# Altium schematic sheet [3] Alimentation tension di.SchDoc("[3] Alimentation tension di")
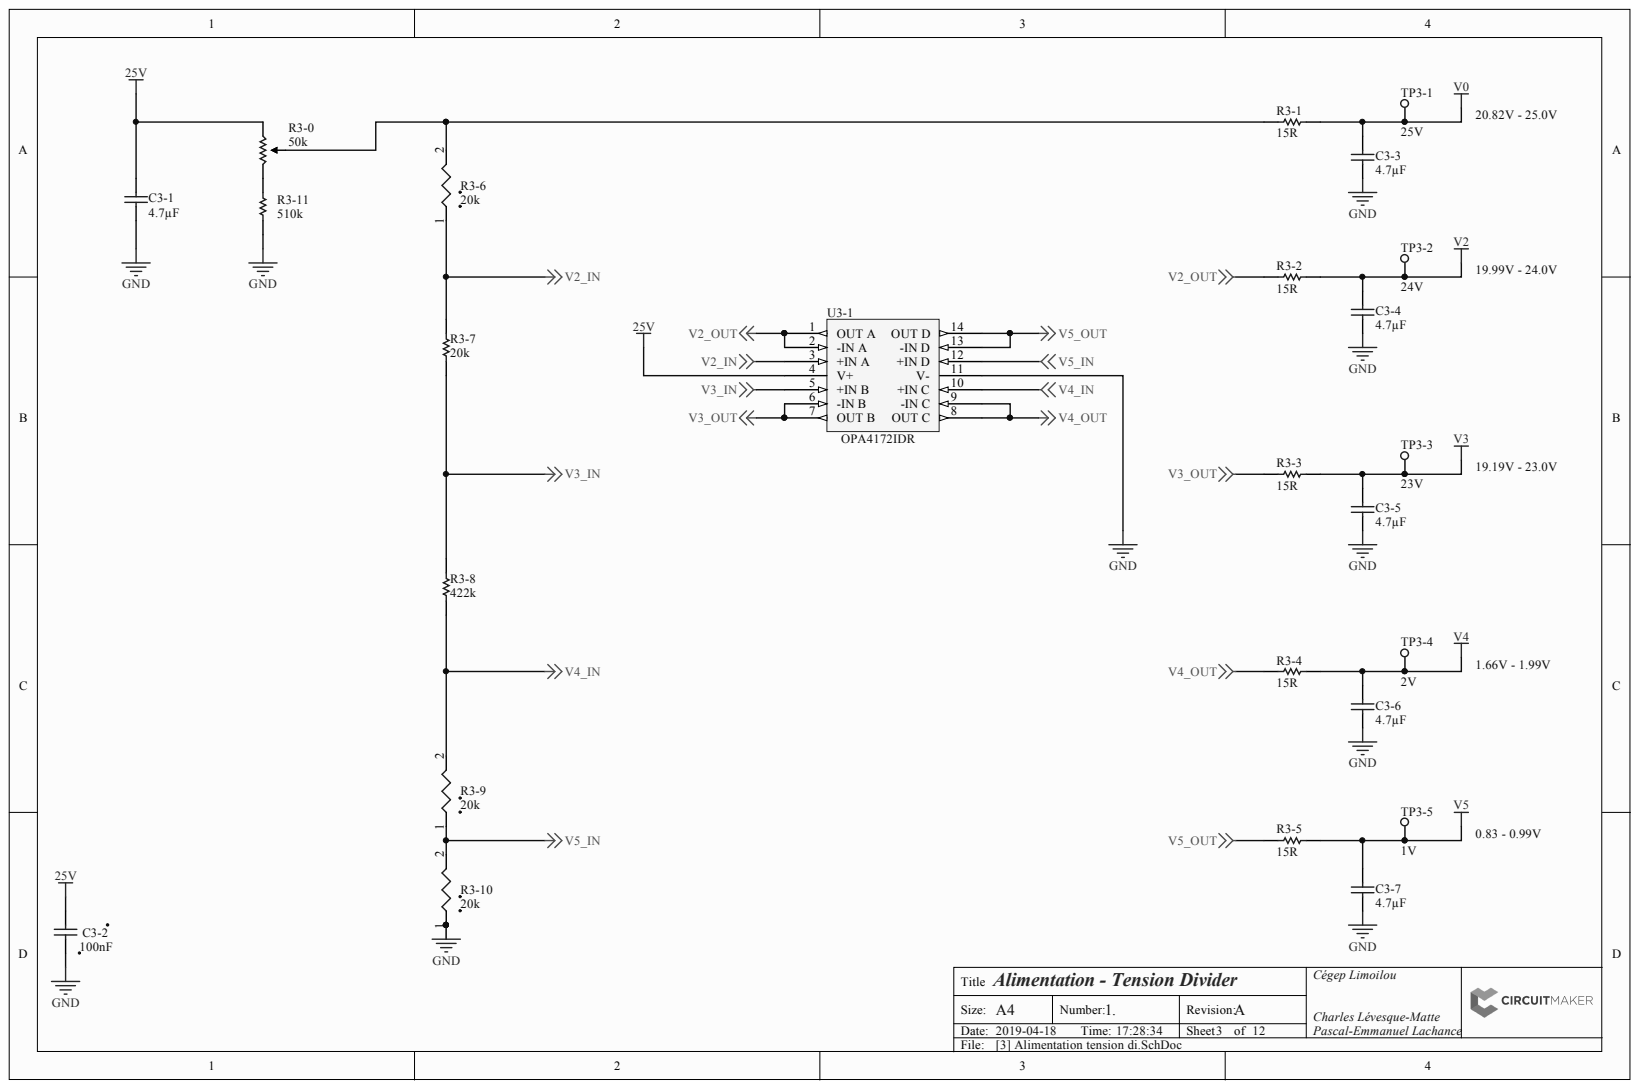
[diagram p0: [3] Alimentation tension di.SchDoc]

## Parts
C3-1  4.7µF  [CAPC3216X180X55ML20T25]
C3-2  100nF  [CAPC1608X90X35ML15T15]
C3-3  4.7µF  [CAPC3216X180X55ML20T25]
C3-4  4.7µF  [CAPC3216X180X55ML20T25]
C3-5  4.7µF  [CAPC3216X180X55ML20T25]
C3-6  4.7µF  [CAPC3216X180X55ML20T25]
C3-7  4.7µF  [CAPC3216X180X55ML20T25]
R3-0  50k  [PCB-vm906pmh4lcf99g1rjc6-1]
R3-1  15R  [PCB-pscu45pf2gecywnmi9sb-1]
R3-2  15R  [PCB-pscu45pf2gecywnmi9sb-1]
R3-3  15R  [PCB-pscu45pf2gecywnmi9sb-1]
R3-4  15R  [PCB-pscu45pf2gecywnmi9sb-1]
R3-5  15R  [PCB-pscu45pf2gecywnmi9sb-1]
R3-6  20k  [PCB-jr3gnteqc9p6l8jw436q-1]
R3-7  20k  [PCB-jr3gnteqc9p6l8jw436q-1]
R3-8  422k  [PCB-r78kbkcw3v9m4vgc944j-1]
R3-9  20k  [PCB-jr3gnteqc9p6l8jw436q-1]
R3-10  20k  [PCB-jr3gnteqc9p6l8jw436q-1]
R3-11  510k  [PCB-fzhvmcfjk8m6ifi8yfyl-1]
TP3-1  25V  [PCB-a7rpxwhzqdkz91v608la-1]
TP3-2  24V  [PCB-a7rpxwhzqdkz91v608la-1]
TP3-3  23V  [PCB-a7rpxwhzqdkz91v608la-1]
TP3-4  2V  [PCB-a7rpxwhzqdkz91v608la-1]
TP3-5  1V  [PCB-a7rpxwhzqdkz91v608la-1]
U3-1  OPA4172IDR  [PCB-a2i7bs9dgoylt2kcgvdk-1]

## Nets
25V: C3-1.2 C3-2.2 R3-0.3 U3-1.4(V+)
GND: C3-1.1 C3-2.1 C3-3.1 C3-4.1 C3-5.1 C3-6.1 C3-7.1 R3-10.1 R3-11.1 U3-1.11(V-)
V0: C3-3.2 R3-1.2 TP3-1.1
V2: C3-4.2 R3-2.2 TP3-2.1
V2_IN: R3-6.1 R3-7.2 U3-1.3(+IN_A)
V2_OUT: R3-2.1 U3-1.1(OUT_A) U3-1.2(-IN_A)
V3: C3-5.2 R3-3.2 TP3-3.1
V3_IN: R3-7.1 R3-8.2 U3-1.5(+IN_B)
V3_OUT: R3-3.1 U3-1.6(-IN_B) U3-1.7(OUT_B)
V4: C3-6.2 R3-4.2 TP3-4.1
V4_IN: R3-8.1 R3-9.2 U3-1.10(+IN_C)
V4_OUT: R3-4.1 U3-1.8(OUT_C) U3-1.9(-IN_C)
V5: C3-7.2 R3-5.2 TP3-5.1
V5_IN: R3-9.1 R3-10.2 U3-1.12(+IN_D)
V5_OUT: R3-5.1 U3-1.13(-IN_D) U3-1.14(OUT_D)
NetR3-0_1: R3-0.1 R3-11.2
NetR3-0_2: R3-0.2 R3-1.1 R3-6.2

## Library data of the parts
C3-1 C3-3-C3-7 = CMP-7356bb72f6b9ac91-2: CL31 Series 4.7 uF 50 V ±10 % Tolerance X7R SMT Multilayer Ceramic Capacitor; Manufacturer Part Number: CL31B475KBHNNNE; Component Kind: Standard; Dielectric Characteristic: X7R; Library Name: CommunityVault; OctopartID: 7356bb72f6b9ac91; Octopart URL: http://octopart.com/cl31b475kbhnnne-samsung-19832969; RoHS: Compliant
C3-2 = CMP-1af8d38ac64bc53f-2: CL10 Series 0.1 uF 50 V ±10% Tolerance X7R SMT Multilayer Ceramic Capacitor; Capacitance: 100 nF; Capacitance Tolerance: ±10%; Component Kind: Standard; Library Name: CommunityVault; Mounting Style: Surface Mount; OctopartID: 1af8d38ac64bc53f; Octopart URL: http://octopart.com/cl10b104kb8nnnc-samsung-7928277; RoHS: Compliant
R3-0 = CMP-53de9ad644d18c19-2: Trimmer Potentiometer 50k Ohm 10% 1/2 Watt 1 Turn 3.15mm Pin Through Hole; Manufacturer Part Number: 3386F-1-503LF; Lead-Free Status: Lead Free; Power Rating: 500 mW; Resistance: 50.0 k?; Temperature Coefficient: -100 ppm/°C to 100 ppm/°C; Voltage Rating (DC): 300 V; Color: Blue; Component Kind: Standard; Library Name: FavoritesLibrary; Mounting Style: Through Hole; OctopartID: 53de9ad644d18c19; Octopart URL: https://octopart.com/3386f-1-503lf-bourns-143977; Operating Temperature: 125 °C (max); Packaging: Tube; REACH SVHC Compliance: No SVHC; Resistance Tolerance: ±10%; RoHS: Compliant; Size-Height: 9.53 mm; Size-Length: 9.53 mm; Size-Width: 4.83 mm; Weight: 845 mg
R3-1-R3-5 = CMP-5db1959e532f8276-1: Res Thick Film 0603 5.1K Ohm 1% 0.1W(1/10W) ±100ppm/C Pad SMD Automotive T/R; Manufacturer Part Number: RMCF0603FT5K10; Lead-Free Status: Lead Free; Power Rating: 100 mW; Resistance: 5.10 k?; Case/Package: 0603; Component Kind: Standard; Library Name: FavoritesLibrary; Mounting Style: Surface Mount; OctopartID: 5db1959e532f8276; Octopart URL: https://octopart.com/rmcf0603ft5k10-stackpole+electronics-19213304; Packaging: Tape & Reel (TR); Resistance Tolerance: ±1%; RoHS: Compliant
R3-6 R3-9 R3-10 = CMP-0edac6f500b3d11d-1: Manufacturer Part Number: RMCF0603FT20K0; Lead-Free Status: Lead Free; Power Rating: 100 mW; Resistance: 20.0 kΩ; Resistance Tolerance: ±1%; Case/Package: 0603; Component Kind: Standard; Library Name: CommunityVault; Mounting Style: Surface Mount; OctopartID: 0edac6f500b3d11d; Octopart URL: https://octopart.com/rmcf0603ft20k0-stackpole+electronics-19213071; Packaging: Tape & Reel (TR); RoHS: Compliant
R3-7 = CMP-0edac6f500b3d11d-1: Res Thick Film 0603 20K Ohm 1% 0.1W(1/10W) ±100ppm/C Pad SMD Automotive T/R; Manufacturer Part Number: RMCF0603FT20K0; Component Kind: Standard; Library Name: CommunityVault; Resistance Tolerance: ±1%
R3-8 = CMP-43b88b974d6f9c7f-1: Res Thick Film 0603 422K Ohm 1% 0.1W(1/10W) ±100ppm/C Pad SMD Automotive T/R; Manufacturer Part Number: RMCF0603FT422K; Lead-Free Status: Lead Free; Power Rating: 100 mW; Resistance: 422 k?; Case/Package: 0603; Component Kind: Standard; Library Name: FavoritesLibrary; Mounting Style: Surface Mount; OctopartID: 43b88b974d6f9c7f; Octopart URL: https://octopart.com/rmcf0603ft422k-stackpole+electronics-19213233; Packaging: Tape & Reel (TR); Resistance Tolerance: ±1%; RoHS: Compliant
R3-11 = CMP-3e7ef65138b7e1b9-1: Res Thick Film 0603 510K Ohm 1% 0.1W(1/10W) ±100ppm/C Pad SMD Automotive T/R; Manufacturer Part Number: RMCF0603FT510K; Lead-Free Status: Lead Free; Power Rating: 100 mW; Resistance: 510 k?; Case/Package: 0603; Component Kind: Standard; Library Name: FavoritesLibrary; Mounting Style: Surface Mount; OctopartID: 3e7ef65138b7e1b9; Octopart URL: https://octopart.com/rmcf0603ft510k-stackpole+electronics-19211183; Packaging: Tape & Reel (TR); Resistance Tolerance: ±1%; RoHS: Compliant
TP3-1-TP3-5 = CMP-5j384tb079h9dvgbp04a-2: Through-hole Testpoint; Component Kind: Standard; Library Name: CommunityVault
U3-1 = CMP-7dadb668dae0a26a-5: 36-V, Single-Supply, 10-MHz, Rail-to-Rail Output, Operational Amplifier 14-SOIC -40 to 125; Lead-Free Status: Lead Free; Component Kind: Standard; Library Name: CommunityVault; Lifecycle Status: Pre-Release; Number of Pins: 14; OctopartID: 7dadb668dae0a26a
